# Altium schematic sheet Power_Supply.SchDoc
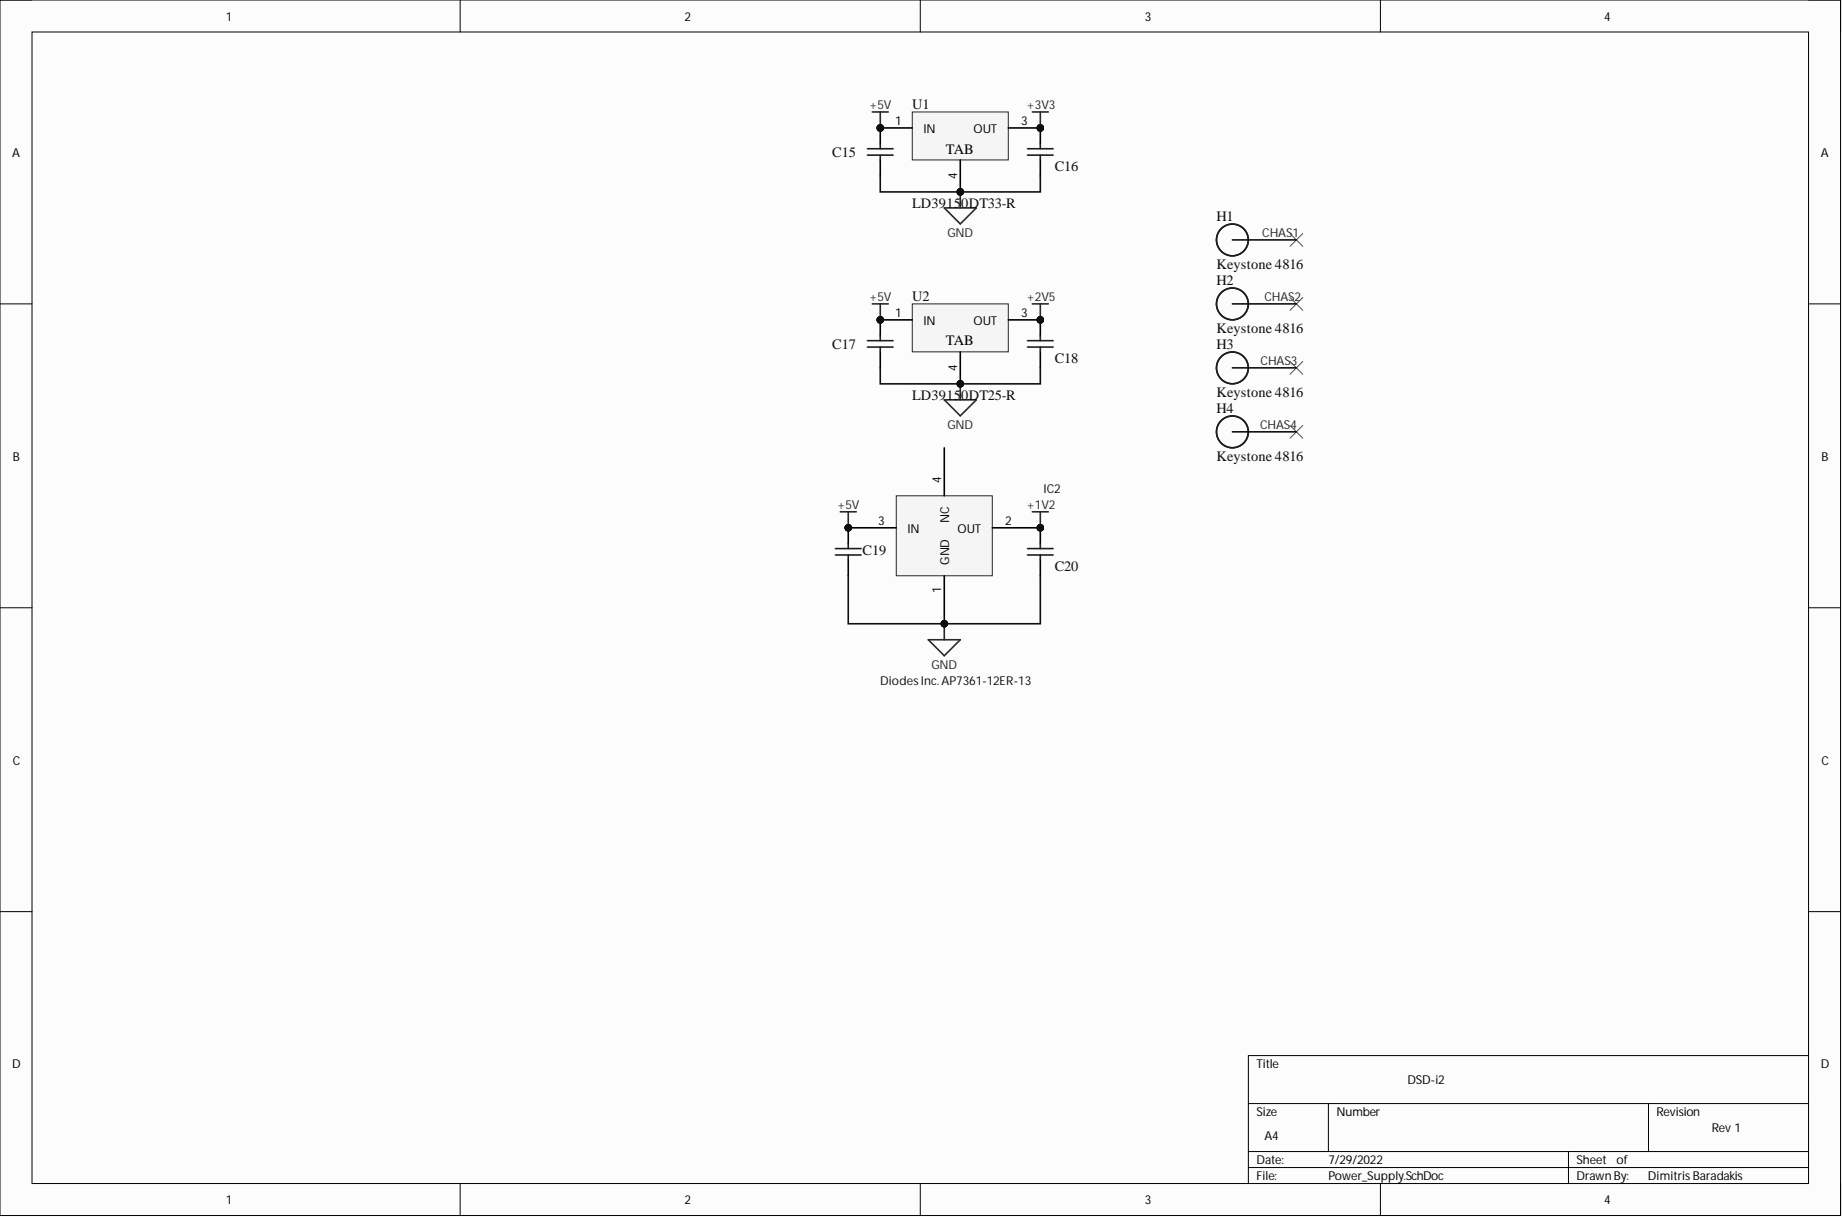
[diagram p0: Power_Supply.SchDoc]

## Parts
C15  Samsung CL03A105MO3NRNH  [Samsung CL03A105MO3NRNH-Footprint-1]  (value not drawn)
C16  Murata GRM033R61A225KE47D  [PCB-wmcyzzrwtxl2d8a5i2w4-1]  (value not drawn)
C17  Samsung CL03A105MO3NRNH  [Samsung CL03A105MO3NRNH-Footprint-1]  (value not drawn)
C18  Murata GRM033R61A225KE47D  [PCB-wmcyzzrwtxl2d8a5i2w4-1]  (value not drawn)
C19  Samsung CL03A105MO3NRNH  [Samsung CL03A105MO3NRNH-Footprint-1]  (value not drawn)
C20  Murata GRM033R61A225KE47D  [PCB-wmcyzzrwtxl2d8a5i2w4-1]  (value not drawn)
H1  Keystone 4816  Manufacturer Part Number: 4816  [PCB-mb8dqtsky67usueely79-1]
H2  Keystone 4816  Manufacturer Part Number: 4816  [PCB-mb8dqtsky67usueely79-1]
H3  Keystone 4816  Manufacturer Part Number: 4816  [PCB-mb8dqtsky67usueely79-1]
H4  Keystone 4816  Manufacturer Part Number: 4816  [PCB-mb8dqtsky67usueely79-1]
IC2  Diodes Inc. AP7361-12ER-13  [Diodes Inc. AP7361-12ER-13-Footprint-1]
U1  LD39150DT33-R  [PCB-a3xbkstxpimrzs2l6x91-1]
U2  LD39150DT25-R  [LD39150DT25-R-Footprint-1]

## Nets
+1V2: C20.2 IC2.2(OUT)
+2V5: C18.2 U2.3
+3V3: C16.2 U1.3
+5V: C15.2 C17.2 C19.2 IC2.3(IN) U1.1 U2.1
GND: C15.1 C16.1 C17.1 C18.1 C19.1 C20.1 IC2.1(GND) U1.4 U2.4
CHAS1:
CHAS2:
CHAS3:
CHAS4:

Not connected: IC2 4(NC)

## Library data of the parts
C15 C17 C19 = CMP-0a3ac1e7a1fcd5fd-1: CL Series 0201 1 uF 16 V ±20% Tolerance X5R Multilayer Ceramic Chip Capacitor; Lead-Free Status: Lead Free; Case/Package: 0201; Component Kind: Standard; Library Name: My Personal Space; Manufacturer Part Number: CL03A105MO3NRNH; Mounting Style: Surface Mount; Octopart Url: https://octopart.com/cl03a105mo3nrnh-samsung-47681184; OctopartID: 0a3ac1e7a1fcd5fd; Packaging: Tape & Reel (TR); RoHS: Compliant
C16 C18 C20 = CMP-61bfac8bfa25be78-2: Cap Ceramic 2.2uF 10VDC X5R 10% SMD 0201 85°C Paper T/R; Capacitance: 2.20 µF; Lead-Free Status: Lead Free; Voltage Rating (DC): 10.0 V; Capacitance Tolerance: ±10%; Component Kind: Standard; Dielectric Characteristic: X5R; Library Name: My Personal Space; Manufacturer Part Number: GRM033R61A225KE47D; Octopart URL: http://octopart.com/grm033r61a225ke47d-murata-71220988; OctopartID: 61bfac8bfa25be78; RoHS: Compliant; Size-Length: 600 µm; Size-Thickness: 300 µm; Size-Width: 300 µm
H1-H4 = CMP-d4321d38e40b0bf5-1: TEKTON 4816 1/2-Inch Drive Shallow Impact Socket Set, SAE, 15-Piece; Lead-Free Status: Lead Free; Color: Natural; Component Kind: Standard; Library Name: My Personal Space; Material: Nylon; Octopart URL: https://octopart.com/4816-keystone-5471; OctopartID: d4321d38e40b0bf5; RoHS: Compliant; Size-Length: 12.7 mm
IC2 = CMP-6c71a4167554b3b6-2: LDO Regulator Pos 1.2V 1A 4-Pin(3+Tab) SOT-223R; Case/Package: SOT-223R; Component Kind: Standard; Library Name: My Personal Space; Lifecycle Status: Active; Manufacturer Part Number: AP7361-12ER-13; Mounting Style: Surface Mount; Octopart Url: https://octopart.com/ap7361-12er-13-diodes+inc.-23936067; OctopartID: 6c71a4167554b3b6; Packaging: Reel; REACH SVHC Compliance: No SVHC; RoHS: Compliant
U1 = CMP-1494527-4: Ultra Low Drop BICMOS Voltage Regulator, 3.3V, 3-Pin DPAK, Tape and Reel; Lead-Free Status: Lead Free; Component Kind: Standard; Library Name: My Personal Space; Lifecycle Status: Active; Manufacturer Part Number: LD39150DT33-R; Octopart Url: https://octopart.com/ld39150dt33-r-stmicroelectronics-2221433; OctopartID: fe61374a3e7e21a6; RoHS: Compliant
U2 = CMP-1497749-4: Ultra Low Drop BICMOS Voltage Regulator, 2.5V, 3-Pin DPAK, Tape and Reel; Lead-Free Status: Lead Free; Component Kind: Standard; Library Name: My Personal Space; Lifecycle Status: Active; Manufacturer Part Number: LD39150DT25-R; Octopart Url: https://octopart.com/ld39150dt25-r-stmicroelectronics-7423468; OctopartID: 7a91b3ea1a1ba556; RoHS: Compliant
